# Altium schematic sheet [04] PCM.SchDoc("[04] PCM")
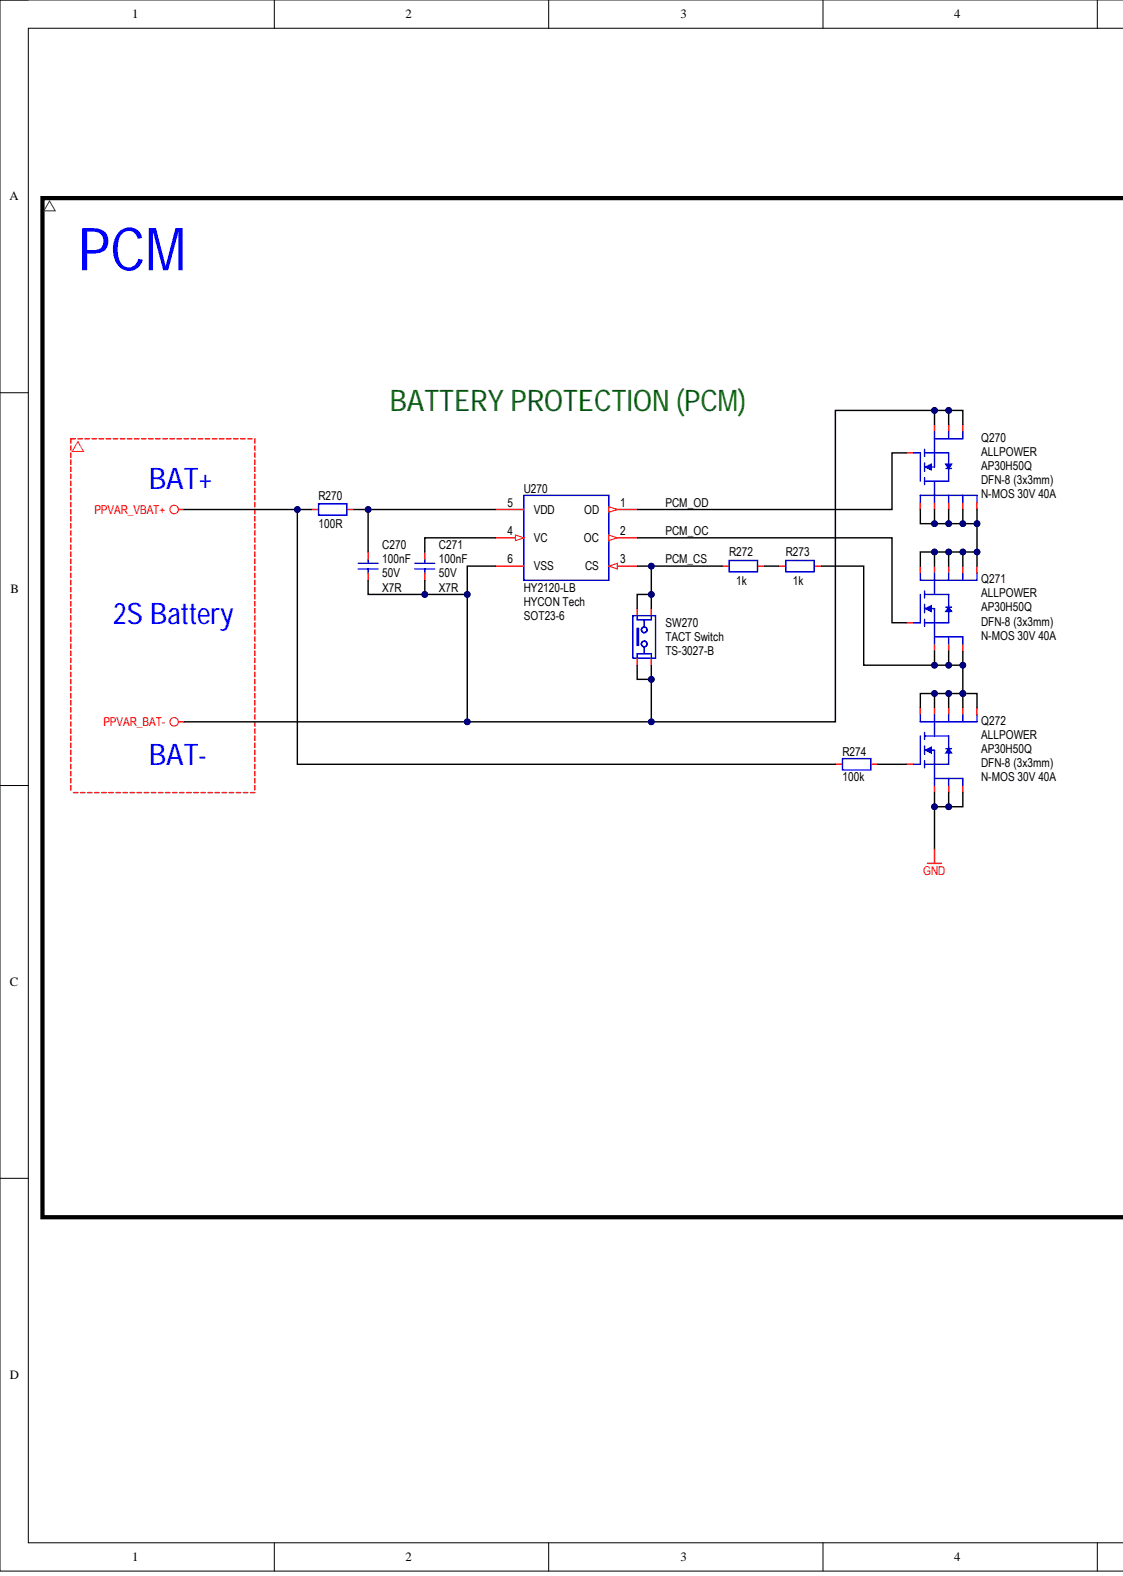
[diagram p0: [04] PCM.SchDoc, left]
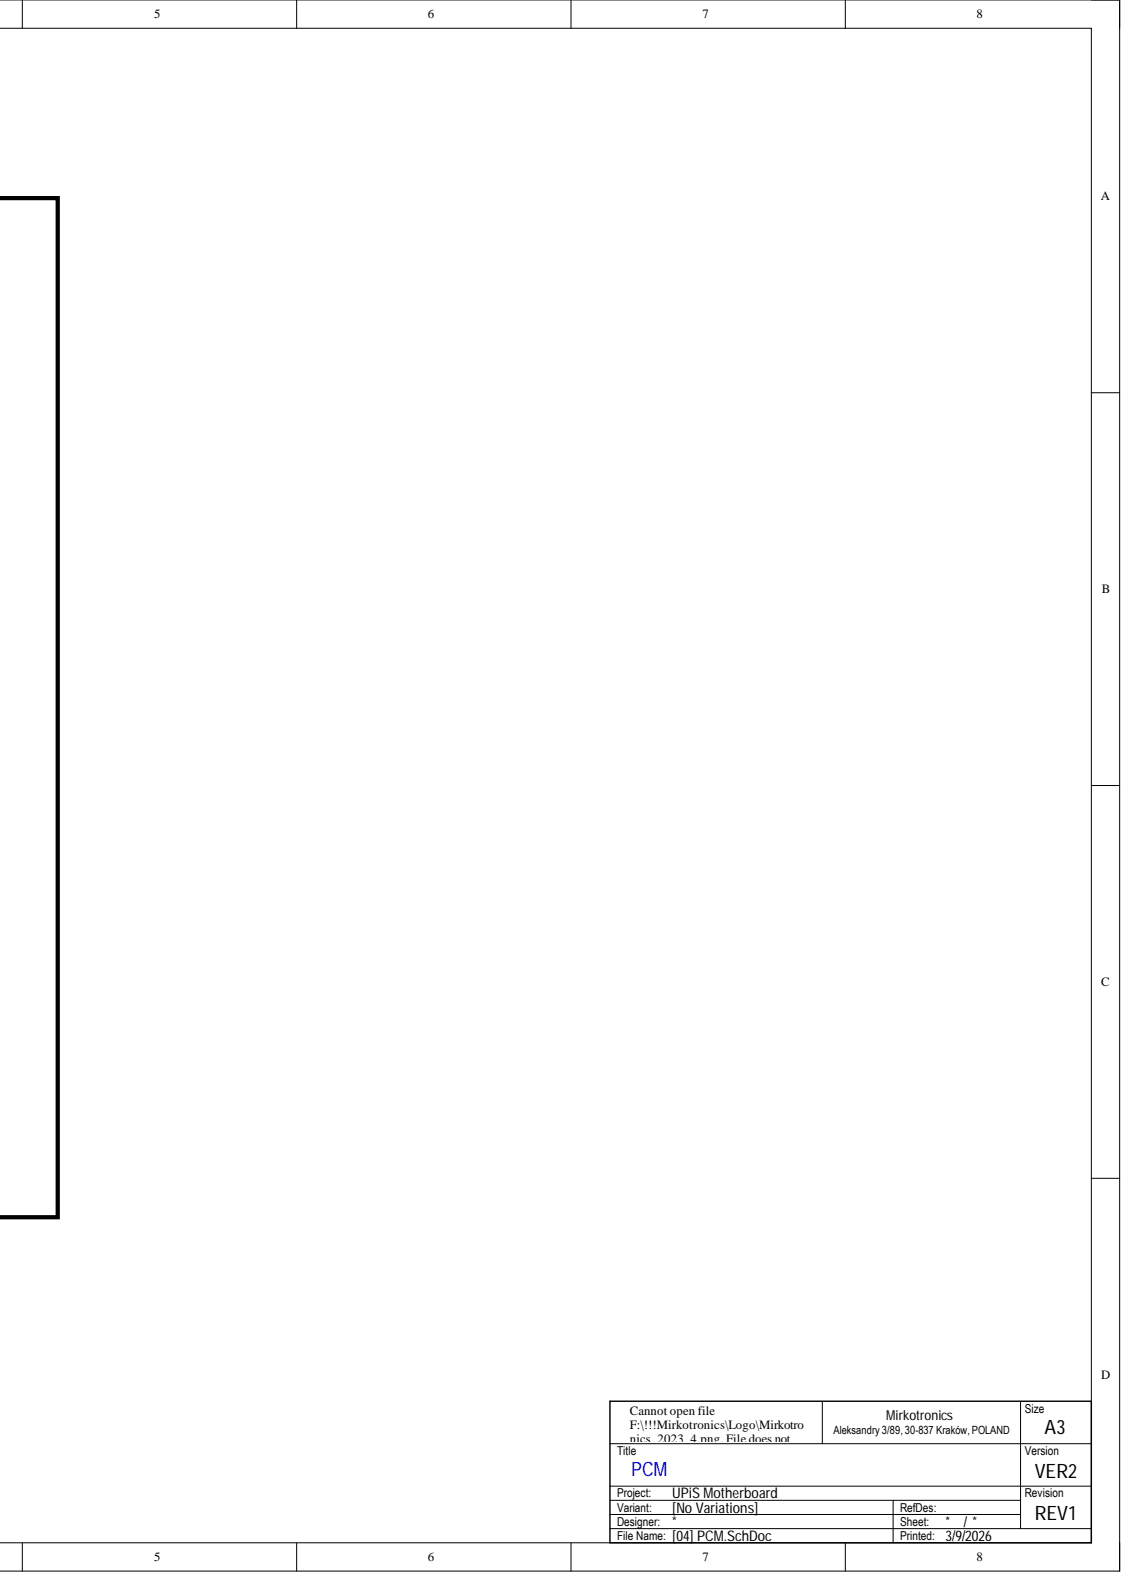
[diagram p1: [04] PCM.SchDoc, right]

## Parts
C270  100nF  Dielectric: X7R  Voltage Rating: 50V
C271  100nF  Dielectric: X7R  Voltage Rating: 50V
Q270  N-MOS 30V 40A  Manufacturer: ALLPOWER  MPN: AP30H50Q  Package (Case): DFN-8 (3x3mm)
Q271  N-MOS 30V 40A  Manufacturer: ALLPOWER  MPN: AP30H50Q  Package (Case): DFN-8 (3x3mm)
Q272  N-MOS 30V 40A  Manufacturer: ALLPOWER  MPN: AP30H50Q  Package (Case): DFN-8 (3x3mm)
R270  100R
R272  1k
R273  1k
R274  100k
SW270  TACT Switch  MPN: TS-3027-B
U270  HY2120-LB  Manufacturer: HYCON Tech  Package (Case): SOT23-6

## Nets
GND: Q272.1 Q272.2 Q272.3
PPVAR_BAT-: C270.2 C271.2 Q270.1 Q270.2 Q270.3 SW270.1 SW270.3 U270.6(VSS)
PPVAR_VBAT+: R270.1 R274.2
PCM_CS: R272.2 SW270.2 SW270.4 U270.3(CS)
PCM_OC: Q271.4 U270.2(OC)
PCM_OD: Q270.4 U270.1(OD)
NetC270_1: C270.1 R270.2 U270.5(VDD)
NetC271_1: C271.1 U270.4(VC)
NetQ270_5: Q270.5 Q270.6 Q270.7 Q270.8 Q270.EP Q271.5 Q271.6 Q271.7 Q271.8 Q271.EP
NetQ271_1: Q271.1 Q271.2 Q271.3 Q272.5 Q272.6 Q272.7 Q272.8 Q272.EP R273.1
NetQ272_4: Q272.4 R274.1
NetR272_1: R272.1 R273.2

## Library data of the parts
C270 C271 = CAP-0004: Capacitor; MLCC; 100nF; 16VDC; X7R; 10%; Standard; SMD; 0402 (1005);; Manufacturer: Murata Electronics; MPN: GRM155R71H104KE14D; Component Body: 1.00 x 0.50mm; Database Table Name: CAPACITOR$; Packaging Type: Tape & Reel; Capacitance: 100nF; Component Height: 0.50mm; Component Kind: Standard; Component Subtype: MLCC; Component Type: Capacitor; Features: Standard; ID: CAP-0004; Mounting Type: SMD; Operating Temperature: -55°C to +125°C; Package (Case): 0402 (1005); ROHS Status: RoHS Compliant; Supplier 1: LCSC; Supplier 2: Mouser; Supplier 3: DigiKey; Supplier Part Number 1: C77020; Tolerance: 10%; Value: 100nF; Library Name: MirFol_db_local.DbLib
Q270-Q272 = TRA_NMOS_SSSGDDDD_EP: Transistor; NMOS;; Component Subtype: P-MOSFET; Component Kind: Standard; Component Type: Transistor; Mounting Type: SMD; Supplier 1: LCSC; Supplier 2: Mouser; Supplier 3: Digikey; Supplier Part Number 1: C2849568; Value: N-MOS 30V 40A; Library Name: Pineberry_Lib.SchLib
R270 = RES-0003: Resistor; Thick Film; 1k; 1%; 62.5mW; 100PPM; 50V; Standard; SMD; 0402 (1005);; Manufacturer: Yageo Corporation; MPN: RC0402FR-07100RL; Component Body: 1.00 x 0.50mm; Database Table Name: RESISTOR$; Packaging Type: Tape & Reel; Component Height: 0.35mm; Component Kind: Standard; Component Subtype: Thick Film; Component Type: Resistor; Features: Standard; ID: RES-0003; Mounting Type: SMD; Operating Temperature: -55°C to +155°C; Package (Case): 0402 (1005); Power Rating: 62.5mW; Resistance: 100R; ROHS Status: RoHS Compliant; Supplier 1: LCSC; Supplier 2: Mouser; Supplier 3: Digikey; Supplier Part Number 1: C106232; TCR: 100PPM; Tolerance: 1%; Value: 100R; Voltage Rating: 50V; Library Name: MirFol_db_local.DbLib
R272 R273 = RES-0003: Resistor; Thick Film; 1k; 1%; 62.5mW; 100PPM; 50V; Standard; SMD; 0402 (1005);; Manufacturer: Yageo Corporation; MPN: RC0402FR-071KL; Component Body: 1.00 x 0.50mm; Database Table Name: RESISTOR$; Packaging Type: Tape & Reel; Component Height: 0.35mm; Component Kind: Standard; Component Subtype: Thick Film; Component Type: Resistor; Features: Standard; ID: RES-0003; Mounting Type: SMD; Operating Temperature: -55°C to +155°C; Package (Case): 0402 (1005); Power Rating: 62.5mW; Resistance: 1k; ROHS Status: RoHS Compliant; Supplier 1: LCSC; Supplier 2: Farnell; Supplier 3: Mouser; Supplier Part Number 1: C106235; Supplier Part Number 2: 9239235; Supplier Part Number 3: 603-RC0402FR-071KL; TCR: 100PPM; Tolerance: 1%; Value: 1k; Voltage Rating: 50V; Library Name: MirFol_db_local.DbLib
R274 = RES-0005: Resistor; Thick Film; 100k; 1%; 62.5mW; 100PPM; 50V; Standard; SMD; 0402 (1005);; Manufacturer: YAGEO; MPN: RC0402FR-07100KL; Component Body: 1.00 x 0.50mm; Database Table Name: RESISTOR$; Packaging Type: Tape & Reel; Component Height: 0.35mm; Component Kind: Standard; Component Subtype: Thick Film; Component Type: Resistor; Features: Standard; ID: RES-0005; Mounting Type: SMD; Operating Temperature: -55°C to +155°C; Package (Case): 0402 (1005); Power Rating: 62.5mW; Resistance: 100k; ROHS Status: RoHS Compliant; Supplier 1: LCSC; Supplier 2: Mouser; Supplier 3: Digikey; Supplier Part Number 1: C60491; Supplier Part Number 2: 603-RC0402FR-07100KL; Supplier Part Number 3: 311-100KLRTR-ND; TCR: 100PPM; Tolerance: 1%; Value: 100k; Voltage Rating: 50V; Library Name: MirFol_db_local.DbLib
SW270 = SWI_TACT_4P_ABAB: Switch; Tactile; Monostable;; Manufacturer: XKB Connectivity; Package (Case): SMD; Component Kind: Standard; Component Subtype: TACT Switch; Component Type: Switch; Mounting Type: SMD; Supplier 1: LCSC; Supplier 2: Mouser; Supplier 3: Digikey; Supplier Part Number 1: C561482; Value: TACT Switch; Library Name: Pineberry_Lib.SchLib
U270 = ICC_HYC_HY2120_SOT23-6: IC; Battery PCM;; Component Kind: Standard; Component Type: IC; Mounting Type: SMD; MPN: HY2120-LB; Operating Temperature: -40°C to +85°C; Supplier 1: LCSC; Supplier 2: Mouser; Supplier 3: DigiKey; Supplier Part Number 1: C168778; Value: HY2120-LB; Library Name: Pineberry_Lib.SchLib
